# Altium schematic sheet RPI-TPS-GK - JTAG.SchDoc
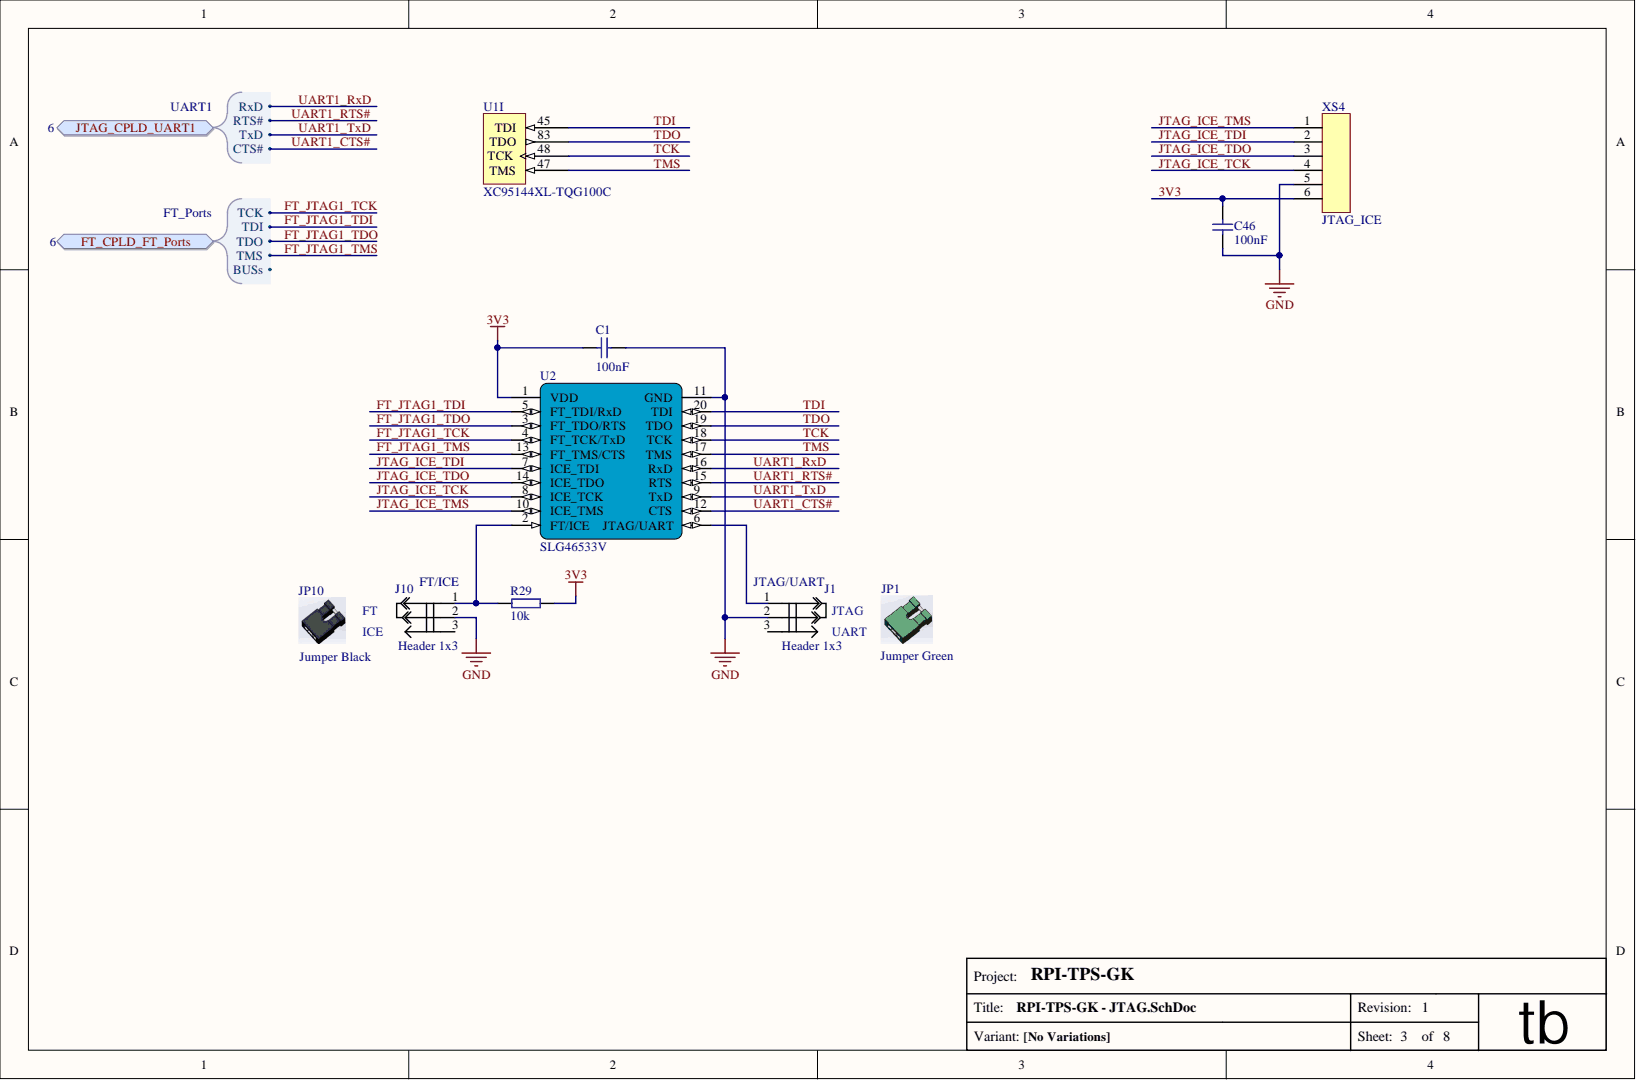
[diagram p0: RPI-TPS-GK - JTAG.SchDoc]

## Parts
C1  value 100nF  [C0603]  (hidden comment: CAP)
C46  value 100nF  [C0603]  (hidden comment: CAP)
J1  JTAG/UART  value Header 1x3  [Jumper_3pin_green]
J10  FT/ICE  value Header 1x3  [Jumper_3pin_black]
JP1  value Jumper Green  Supplier 1 Part: Jumper  (hidden comment: VCC_sel)  (mechanical)
JP10  value Jumper Black  (hidden comment: VCC_sel)  (mechanical)
R29  value 10k  [0603_RESISTOR]  (hidden comment: Resistor)
U1  value XC95144XL-TQG100C  Supplier 1 Part: XC95144XL-TQG100C  [TQ100 - XC95144XL]  (hidden comment: XC95144XL-SQ100)  (part I)
U2  SLG46533V  [STQFN-20]
XS4  JTAG_ICE  [Conn_6p]

## Ports
FT_CPLD_FT_Ports, FT_CPLD_FT_Ports.BUSs, FT_CPLD_FT_PORTS.BUSS.ACB0, FT_CPLD_FT_PORTS.BUSS.ACB1, FT_CPLD_FT_PORTS.BUSS.ACB2, FT_CPLD_FT_PORTS.BUSS.ACB3, FT_CPLD_FT_PORTS.BUSS.ACB4, FT_CPLD_FT_PORTS.BUSS.ACB5, FT_CPLD_FT_PORTS.BUSS.ACB6, FT_CPLD_FT_PORTS.BUSS.ACB7, FT_CPLD_FT_Ports.BUSs.ACB[7..0], FT_CPLD_FT_PORTS.BUSS.ADB4, FT_CPLD_FT_PORTS.BUSS.ADB5, FT_CPLD_FT_PORTS.BUSS.ADB6, FT_CPLD_FT_PORTS.BUSS.ADB7, FT_CPLD_FT_Ports.BUSs.ADB[7..4], FT_CPLD_FT_PORTS.BUSS.BCB0, FT_CPLD_FT_PORTS.BUSS.BCB1, FT_CPLD_FT_PORTS.BUSS.BCB2, FT_CPLD_FT_PORTS.BUSS.BCB3, FT_CPLD_FT_PORTS.BUSS.BCB4, FT_CPLD_FT_PORTS.BUSS.BCB5, FT_CPLD_FT_PORTS.BUSS.BCB6, FT_CPLD_FT_PORTS.BUSS.BCB7, FT_CPLD_FT_Ports.BUSs.BCB[7..0], FT_CPLD_FT_PORTS.BUSS.BDB4, FT_CPLD_FT_PORTS.BUSS.BDB5, FT_CPLD_FT_PORTS.BUSS.BDB6, FT_CPLD_FT_PORTS.BUSS.BDB7, FT_CPLD_FT_Ports.BUSs.BDB[7..4], FT_CPLD_FT_Ports.BUSs.PWREN#, FT_CPLD_FT_Ports.BUSs.SUSPEND#, FT_CPLD_FT_Ports.TCK, FT_CPLD_FT_Ports.TDI, FT_CPLD_FT_Ports.TDO, FT_CPLD_FT_Ports.TMS, JTAG_CPLD_UART1, JTAG_CPLD_UART1.CTS#, JTAG_CPLD_UART1.RTS#, JTAG_CPLD_UART1.RxD, JTAG_CPLD_UART1.TxD

## Nets
GND: C1.2 C46.2 J1.2 J10.2 U2.11(GND) XS4.5
3V3: C1.1 C46.1 R29.1 U2.1(VDD) XS4.6
FT_JTAG1_TCK: U2.4(FT_TCK/TxD) ; port FT_CPLD_FT_Ports
FT_JTAG1_TDI: U2.5(FT_TDI/RxD) ; port FT_CPLD_FT_Ports
FT_JTAG1_TDO: U2.3(FT_TDO/RTS) ; port FT_CPLD_FT_Ports
FT_JTAG1_TMS: U2.13(FT_TMS/CTS) ; port FT_CPLD_FT_Ports
JTAG_ICE_TCK: U2.8(ICE_TCK) XS4.4
JTAG_ICE_TDI: U2.7(ICE_TDI) XS4.2
JTAG_ICE_TDO: U2.14(ICE_TDO) XS4.3
JTAG_ICE_TMS: U2.10(ICE_TMS) XS4.1
TCK: U1.48(TCK) U2.18(TCK)
TDI: U1.45(TDI) U2.20(TDI)
TDO: U1.83(TDO) U2.19(TDO)
TMS: U1.47(TMS) U2.17(TMS)
UART1_CTS#: U2.12(CTS) ; port JTAG_CPLD_UART1
UART1_RTS#: U2.15(RTS) ; port JTAG_CPLD_UART1
UART1_RxD: U2.16(RxD) ; port JTAG_CPLD_UART1
UART1_TxD: U2.9(TxD) ; port JTAG_CPLD_UART1
NetJ1_1: J1.1 U2.6(JTAG/UART)
NetJ10_1: J10.1 R29.2 U2.2(FT/ICE)
BUSs: ; port FT_CPLD_FT_Ports
N00133: ; port FT_CPLD_FT_Ports
N00134: ; port FT_CPLD_FT_Ports
N00147[7..0]:
N00156[7..4]:
N00161[7..0]:
N00170[7..4]:

Not connected: J1 3; J10 3

## Library data of the parts
C1 C46 = CAP: Type of Component: Ceramic Capacitor; Component Kind: Standard; Package / Case: 0603; Supplier 1: aliexpress.com; Supplier 1 Link: aliexpress.com/item/100pcs-lot-100nF-X7R-Error-10-50V-0603-smd-capacitor/32318089455.html?spm=2114.03010208.3.2.PDNk2A&ws_ab_test=searchweb201556_8,searchweb201602_1_10065_10068_112_10069_110_111_418_10017_109_108_10060_10061_10062_10057_10039_10056_10055_10054_10059_10058_10073_10070_10052_10053_10050_10051,searchweb201603_1&btsid=c39062ca-3db8-4562-8db0-c782461646c7; Supplier 2: http://imrad.com.ua/; Supplier 2 Link: http://imrad.com.ua/ru/cc0603krx7r8bb104; Supplier 2 Part: 182925; Supplier 3: http://voron.ua; Supplier 3 Link: http://voron.ua/catalog/001832; Supplier 3 Part: 001832; Library Name: RPI-VITA.IntLib
J1 J10 = jumper_3pin: Type of Component: Header for jumper 2 pin; Component Kind: Standard; Package / Case: Header 1 x 3; Supplier 1: aliexpress.com; Supplier 1 Link: aliexpress.com/item/1Set-20PCS-40Pin-2-54mm-Single-Row-Straight-Male-Pin-Header-Connector-Strip-For-PBC-Ardunio/32329088739.html?spm=2114.03010208.3.2.0ijeiM&ws_ab_test=searchweb201556_1,searchweb201644_1_505_506_503_504_502_10001_10002_10017_10010_10005_10011_10006_10003_10004_10009_10007_10008,searchweb201560_5,searchweb1451318400_-1,searchweb1451318411_6452&btsid=d8fe2734-cbe4-429c-a307-e5400297e31b; Supplier 2: http://imrad.com.ua; Supplier 2 Link: http://imrad.com.ua/ru/pls-40-product-122266; Supplier 2 Part: 202826; Supplier 3: http://voron.ua; Supplier 3 Link: http://voron.ua/catalog/000631; Supplier 3 Part: 000631; Library Name: RPI-VITA.IntLib
JP1 = jumper green: Manufacturer: Great Electric; Manufacturer Part: Jumper Cap Shunts Short Circuit Cap; Package / Case: 2.54mm; Supplier 1: aliexpress.com; Supplier 1 Link: http://www.aliexpress.com/item/500pcs-lot-Asssorted-Color-2-54mm-Standard-Circuit-Board-Jumper-Cap-Shunts-Short-Circuit-Cap-CGKCH119/32344305619.html?spm=2114.03010208.3.80.nPPmoy&ws_ab_test=searchweb201556_1,searchweb201644_1_505_506_503_504_502_10001_10002_10017_10010_10005_10011_10006_10003_10004_10009_10007_10008,searchweb201560_5,searchweb1451318400_-1,searchweb1451318411_6452&btsid=16d11777-edde-4755-b8b5-9adacb495b09; Type of Component: Jumper; Library Name: RPI-VITA.IntLib
JP10 = jumper black: Manufacturer: Great Electric; Manufacturer Part: Jumper Cap Shunts Short Circuit Cap; Package / Case: 2.54mm; Supplier 1: aliexpress.com; Supplier 1 Link: http://www.aliexpress.com/item/500pcs-lot-Asssorted-Color-2-54mm-Standard-Circuit-Board-Jumper-Cap-Shunts-Short-Circuit-Cap-CGKCH119/32344305619.html?spm=2114.03010208.3.80.nPPmoy&ws_ab_test=searchweb201556_1,searchweb201644_1_505_506_503_504_502_10001_10002_10017_10010_10005_10011_10006_10003_10004_10009_10007_10008,searchweb201560_5,searchweb1451318400_-1,searchweb1451318411_6452&btsid=16d11777-edde-4755-b8b5-9adacb495b09; Supplier 1 Part: Jumper Black; Supplier 3: http://voron.ua/; Supplier 3 Link: http://voron.ua/catalog/000471; Supplier 3 Part: 000471; Type of Component: Jumper Black; Library Name: RPI-VITA.IntLib
R29 = Resistor: Manufacturer: Vishay / Dale; Manufacturer Part: CRCW060310K0FKEA; Component Kind: Standard; Manufacturer Link: http://www.vishay.com/product?docid=20035; Package / Case: 0603; Supplier 1: aliexpress.com; Supplier 1 Link: aliexpress.com/store/product/0603-SMD-Resistor-5-10K-ohm-5000pcs-lot/506373_32307351301.html?storeId=506373&spm=2114.12010208.100007.103.XAQnpm; Supplier 1 Part: 0603 10k; Supplier 2: http://imrad.com.ua/; Supplier 2 Link: http://imrad.com.ua/ru/rc0603jr-0710kl; Supplier 2 Part: 183440; Supplier 3: http://www.kosmodrom.com.ua; Supplier 3 Link: http://www.kosmodrom.com.ua/prodlist.php?page=0&name=%280603%29+10+kom&okbutton=%CF%EE%E8%F1%EA+%EF%EE+%F1%EA%EB%E0%E4%F3; Supplier 3 Part: (0603) 10 kom; Type of Component: Resistor; Library Name: RPI-VITA.IntLib
U1 = XC95144XL-SQ100: The XC95144XL is a 3.3V CPLD targeted for high-performance,  low-voltage applications in leading-edge communications  and computing systems.; Manufacturer: Xilinx Inc; Manufacturer Part: XC95144XL-10TQG100C; Component Kind: Standard; Manufacturer Link: http://xgoogle.xilinx.com/search?output=xml_no_dtd&ie=UTF-8&oe=UTF-8&client=xilinx&proxystylesheet=xilinx&site=EntireSite&filter=0&num=200&sort=date:D:L:d1&getfields=*&show_dynamic_navigation=1&requiredfields=-Archived%3Atrue&q=XC95144XL-10TQG100C&as_q=; Package / Case: TQG-100C; Supplier 1: HK-Ada; Type of Component: CPLD; Library Name: RPI-VITA.IntLib
U2 = SLG46533: GreenPAK Programmable Mixed Signal Array; Manufacturer: Silego; Type of Component: GPAK-5; Component Kind: Standard; Manufacturer Link: http://www.silego.com/products/greenpak5.html; Manufacturer Part: SLG46533V; Package / Case: STQFN-20; Supplier 1: Silego.com; Supplier 1 Link: http://www.silego.com/buy/index.php?main_page=product_info&cPath=67&products_id=497; Supplier 1 Part: SLG46533V; Value: SLG46533V; Library Name: RPI-VITA.IntLib
XS4 = conn_6p: PLS-6 2.54mm Pitch; Type of Component: Header 1x6; Value: Header 1x6; Component Kind: Standard; Package / Case: Header 1x6; Supplier 1: aliexpress.com; Supplier 1 Link: aliexpress.com/item/1Set-20PCS-40Pin-2-54mm-Single-Row-Straight-Male-Pin-Header-Connector-Strip-For-PBC-Ardunio/32329088739.html?spm=2114.03010208.3.2.0ijeiM&ws_ab_test=searchweb201556_1,searchweb201644_1_505_506_503_504_502_10001_10002_10017_10010_10005_10011_10006_10003_10004_10009_10007_10008,searchweb201560_5,searchweb1451318400_-1,searchweb1451318411_6452&btsid=d8fe2734-cbe4-429c-a307-e5400297e31b; Supplier 2: http://imrad.com.ua; Supplier 2 Link: http://imrad.com.ua/ru/pls-40-product-122266; Supplier 2 Part: 202826; Supplier 3: http://voron.ua; Supplier 3 Link: http://voron.ua/catalog/000631; Supplier 3 Part: 000631; Library Name: RPI-VITA.IntLib
